# Altium schematic sheet Sheet_Power.SchDoc
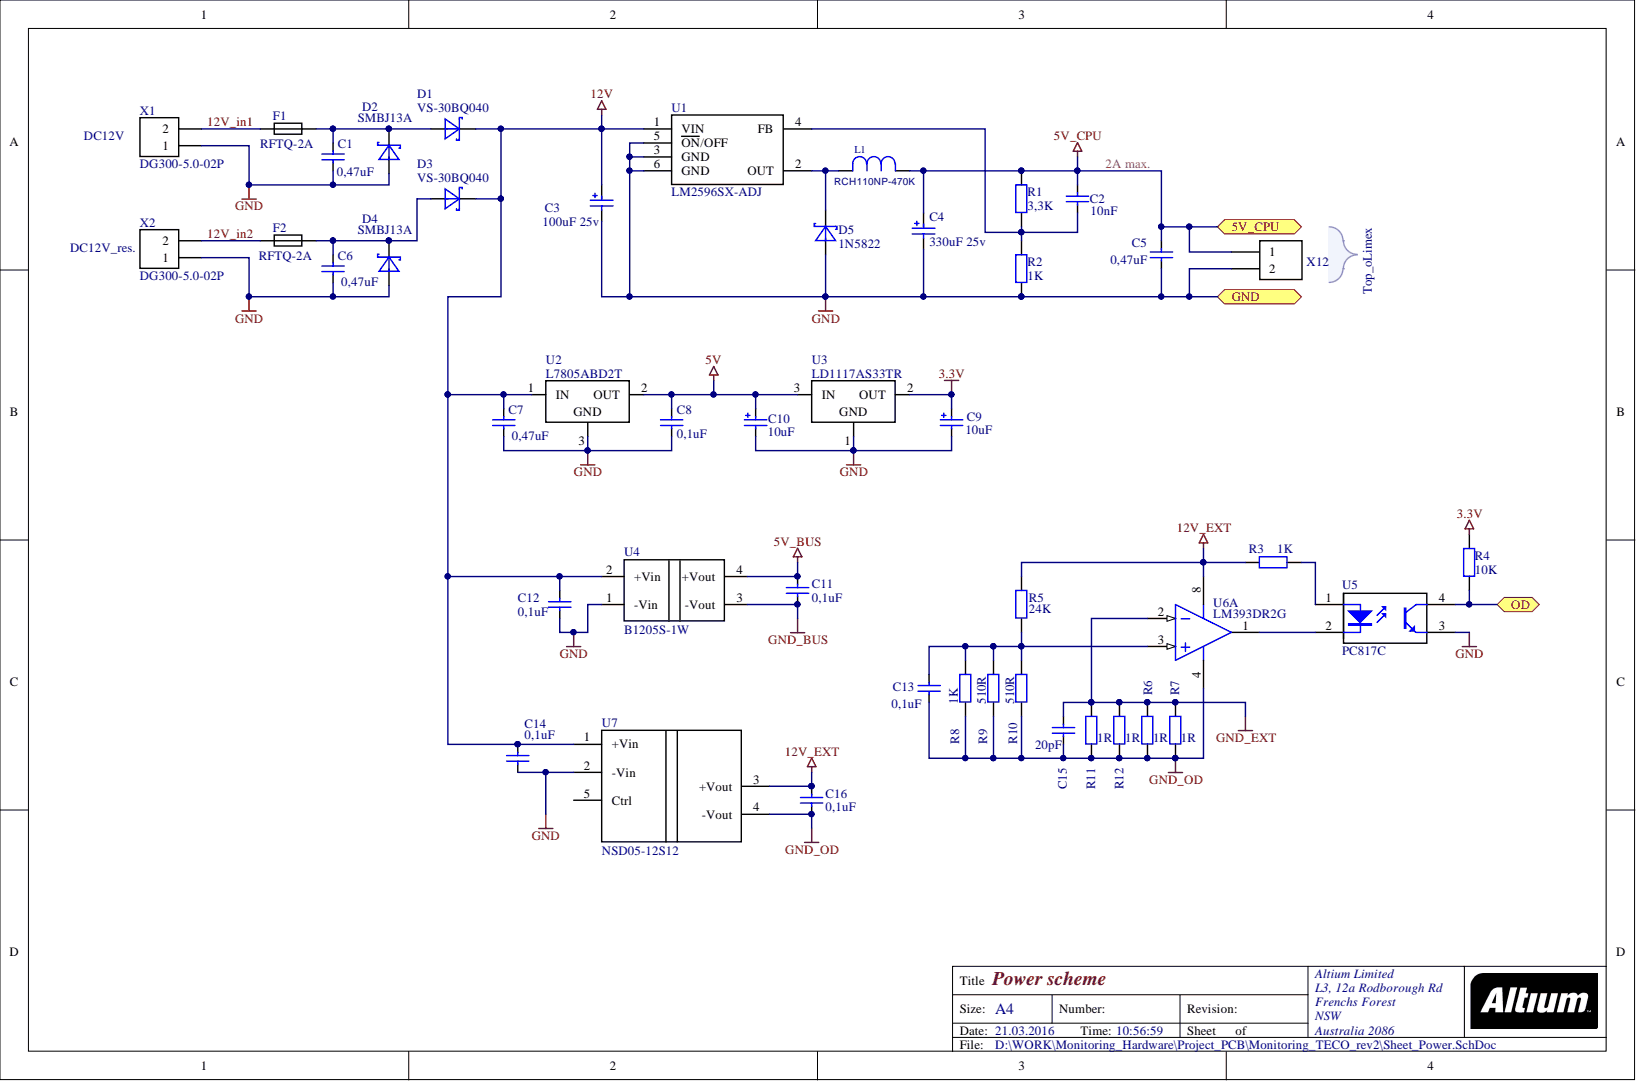
[diagram p0: Sheet_Power.SchDoc]

## Parts
C1  value 0,47uF  [C_1206]  (hidden comment: Cap_Semi)
C2  value 10nF  [C_0805]  (hidden comment: Cap_Semi)
C3  value 100uF 25v  [8x11]  (hidden comment: Cap_Polar)
C4  value 330uF 25v  [10x16]  (hidden comment: Cap_Polar)
C5  value 0,47uF  [C_1206]  (hidden comment: Cap_Semi)
C6  value 0,47uF  [C_1206]  (hidden comment: Cap_Semi)
C7  value 0,47uF  [C_1206]  (hidden comment: Cap_Semi)
C8  value 0,1uF  [C_0805]  (hidden comment: Cap_Semi)
C9  value 10uF  [5x11]  (hidden comment: Cap_Polar)
C10  value 10uF  [5x11]  (hidden comment: Cap_Polar)
C11  value 0,1uF  [C_0805]  (hidden comment: Cap_Semi)
C12  value 0,1uF  [C_0805]  (hidden comment: Cap_Semi)
C13  value 0,1uF  [C_0805]  (hidden comment: Cap_Semi)
C14  value 0,1uF  [C_0805]  (hidden comment: Cap_Semi)
C15  value 20pF  [C_0805]  (hidden comment: Cap_Semi)
C16  value 0,1uF  [C_0805]  (hidden comment: Cap_Semi)
D1  VS-30BQ040  [SMC]
D2  SMBJ13A  [SMB]
D3  VS-30BQ040  [SMC]
D4  SMBJ13A  [SMB]
D5  1N5822  [DO-201]
F1  RFTQ-2A  [Fuse RFTQ]
F2  RFTQ-2A  [Fuse RFTQ]
L1  RCH110NP-470K  [RCH-110]
R1  value 3,3K  [R_0805]  (hidden comment: Resistor)
R2  value 1K  [R_0805]  (hidden comment: Resistor)
R3  value 1K  [R_0805]  (hidden comment: Resistor)
R4  value 10K  [R_0805]  (hidden comment: Resistor)
R5  value 24K  [R_0805]  (hidden comment: Resistor)
R6  value 1R  [R_1206]  (hidden comment: Resistor)
R7  value 1R  [R_1206]  (hidden comment: Resistor)
R8  value 1K  [R_0805]  (hidden comment: Resistor)
R9  value 510R  [R_0805]  (hidden comment: Resistor)
R10  value 510R  [R_0805]  (hidden comment: Resistor)
R11  value 1R  [R_1206]  (hidden comment: Resistor)
R12  value 1R  [R_1206]  (hidden comment: Resistor)
U1  LM2596SX-ADJ  [D2PAK_5p]
U2  L7805ABD2T  [D2PAK_3p]
U3  LD1117AS33TR  [SOT-223_N]
U4  B1205S-1W  [SIP4]
U5  PC817C  [DIP-4]
U6  LM393DR2G  [SO-8_L]  (part A)
U7  NSD05-12S12  [NSD05]
X1  DG300-5.0-02P  [5mm 2]
X2  DG300-5.0-02P  [5mm 2]
X12  PLS-2  [PLS-2-3D]  (value not drawn)

## Ports
5V_CPU, GND, OD

## Nets
3.3V: C9.1 R4.2 U3.2
5V: C8.2 C10.1 U2.2 U3.3
5V_BUS: C11.1 U4.4(+Vout)
12V: C3.1 C7.2 C12.1 C14.2 D1.2 D3.2 U1.1(VIN) U2.1 U4.2(+Vin) U7.1(+Vin)
12V_EXT: C16.2 R3.1 R5.2 U6.8 U7.3(+Vout)
GND_BUS: C11.2 U4.3(-Vout)
GND_EXT: C15.2 R6.2 R7.2 R11.2 R12.2 U6.2
GND_OD: C13.1 C15.1 C16.1 R6.1 R7.1 R8.1 R9.1 R10.1 R11.1 R12.1 U6.4 U7.4(-Vout)
5V_CPU: C2.1 C4.1 C5.2 L1.1 R1.2 X12.1 ; port 5V_CPU
12V_IN1: F1.2 X1.2
12V_IN2: F2.2 X2.2
GND: C1.1 C3.2 C4.2 C5.1 C6.1 C7.1 C8.1 C9.2 C10.2 C12.2 C14.1 D2.1 D4.1 D5.1 R2.1 U1.3(GND) U1.5(ON/OFF) U1.6(GND) U2.3 U3.1 U4.1(-Vin) U5.3 U7.2(-Vin) X1.1 X2.1 X12.2 ; port GND
OD: R4.1 U5.4 ; port OD
NetC1_2: C1.2 D1.1 D2.2 F1.1
NetC2_2: C2.2 R1.1 R2.2 U1.4(FB)
NetC6_2: C6.2 D3.1 D4.2 F2.1
NetC13_2: C13.2 R5.1 R8.2 R9.2 R10.2 U6.3
NetD5_2: D5.2 L1.2 U1.2(OUT)
NetR3_2: R3.2 U5.1
NetU5_2: U5.2 U6.1

Not connected: U7 5(Ctrl)

## Library data of the parts
C1 C2 C5-C8 C11-C16 = Cap_Semi: Capacitor semi; Component Kind: Standard; Library Name: Capacitor.SchLib
C3 C4 = Cap_Polar: Capacitor Polar; Component Kind: Standard; Library Name: Capacitor.SchLib
C9 C10 = Capacitor Polar: Component Kind: Standard; Description: Capacitor polar; Library Name: Capacitor.SchLib
D1 D3 = VS-30BQ040: Diode Schottky; Component Kind: Standard; Library Name: Diode.SchLib
D2 D4 = SMBJ13A: Supressor; Component Kind: Standard; Library Name: Diode.SchLib
D5 = 1N5822: Diode Schottky; Component Kind: Standard; Library Name: Diode.SchLib
F1 F2 = Fuse: Component Kind: Standard; Description: Fuse; Library Name: Other.SchLib
L1 = Inductor: Component Kind: Standard; Description: Inductor; Value: RCH110NP-470K; Library Name: Induction.SchLib
U1 = LM2596SX-ADJ: Easy Switcher 3.0A Step-Down Voltage Regulator; Component Kind: Standard; Library Name: Power.SchLib
U2 = L7805ABD2T: Voltage Regulators; Component Kind: Standard; Library Name: Power.SchLib
U3 = LD1117AS33TR: Voltage Regulators 800mA; Component Kind: Standard; Library Name: Power.SchLib
U4 = B0505S-1W: DC/DC converter; Component Kind: Standard; Library Name: Power.SchLib
U5 = PC817: Optocoupler; Component Kind: Standard; Library Name: Chip_Packaging.SchLib
U6 = LM393DR2G: Dual Comparators; Component Kind: Standard; Library Name: Chip_Packaging.SchLib
U7 = NSD05-12S12: DC/DC Regulated Single Output; Component Kind: Standard; Library Name: Power.SchLib
X1 X2 = DG300_2pin: Connector 5mm; : DG300-5.0-02P; Component Kind: Standard; Library Name: Connect.SchLib
X12 = PLS-2: Header, 2-Pin; Component Kind: Standard; LatestRevisionDate: 17-Jul-2002; LatestRevisionNote: Re-released for DXP Platform.; Library Name: Connect.SchLib; Publisher: Altium Limited
R1-R12 = Resistor: Component Kind: Standard; Description: Resistor; Library Name: Resistors.SchLib
